# Altium schematic sheet SDCARD.SchDoc
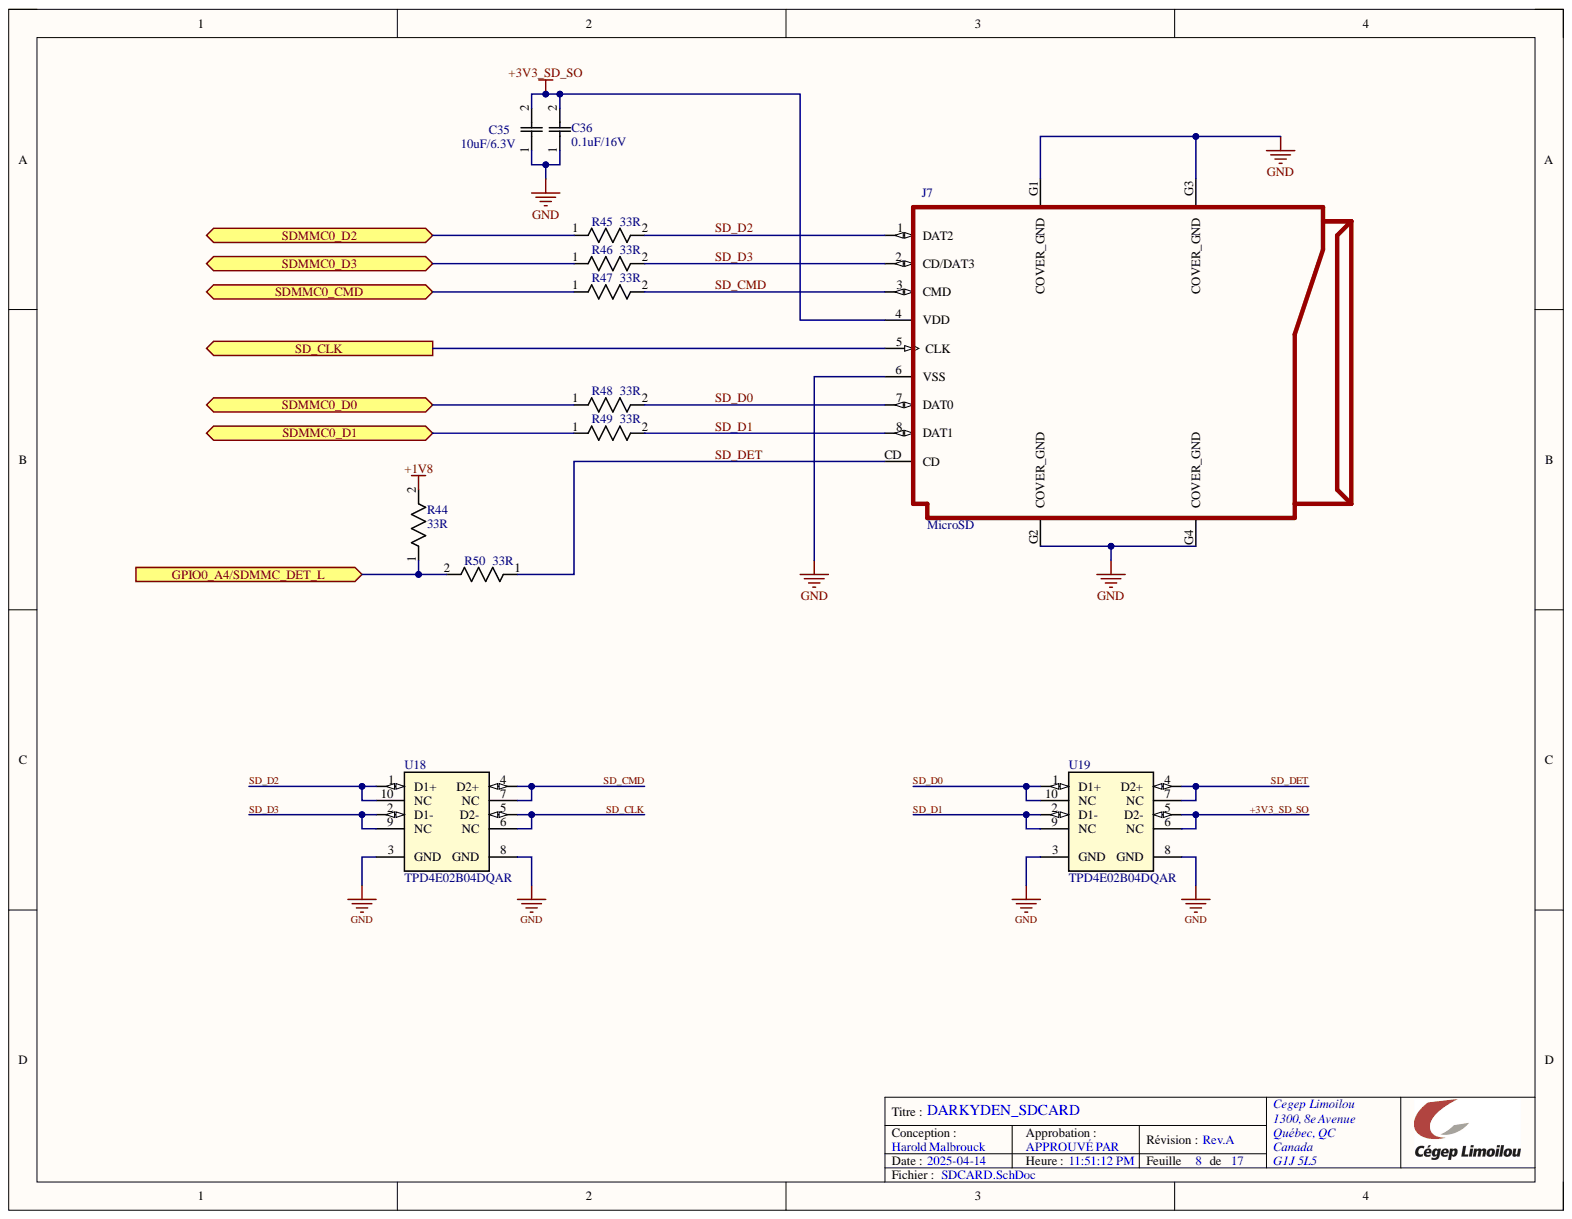
[diagram p0: SDCARD.SchDoc]

## Parts
C35  10uF/6.3V  [CAP_CC0805_YAG]
C36  0.1uF/16V  [CAP_CC0805_YAG]
J7  MicroSD  [CUI_MSD-4-A]
R44  33R  [RES_0816_SUS]
R45  33R  [RES_0816_SUS]
R46  33R  [RES_0816_SUS]
R47  33R  [RES_0816_SUS]
R48  33R  [RES_0816_SUS]
R49  33R  [RES_0816_SUS]
R50  33R  [RES_0816_SUS]
U18  TPD4E02B04DQAR  [DQA0010A]
U19  TPD4E02B04DQAR  [DQA0010A]

## Ports
GPIO0_A4/SDMMC_DET_L, SD_CLK, SDMMC0_CMD, SDMMC0_D0, SDMMC0_D1, SDMMC0_D2, SDMMC0_D3

## Nets
+1V8: R44.2
GND: C35.1 C36.1 J7.6(VSS) J7.G1(COVER_GND) J7.G2(COVER_GND) J7.G3(COVER_GND) J7.G4(COVER_GND) U18.3(GND) U18.8(GND) U19.3(GND) U19.8(GND)
+3V3_SD_SO: C35.2 C36.2 J7.4(VDD) U19.5(D2-) U19.6(NC)
GPIO0_A4/SDMMC_DET_L: R44.1 R50.2 ; port GPIO0_A4/SDMMC_DET_L
SD_CLK: J7.5(CLK) U18.5(D2-) U18.6(NC) ; port SD_CLK
SD_CMD: J7.3(CMD) R47.2 U18.4(D2+) U18.7(NC)
SD_D0: J7.7(DAT0) R48.2 U19.1(D1+) U19.10(NC)
SD_D1: J7.8(DAT1) R49.2 U19.2(D1-) U19.9(NC)
SD_D2: J7.1(DAT2) R45.2 U18.1(D1+) U18.10(NC)
SD_D3: J7.2(CD/DAT3) R46.2 U18.2(D1-) U18.9(NC)
SD_DET: J7.CD R50.1 U19.4(D2+) U19.7(NC)
SDMMC0_CMD: R47.1 ; port SDMMC0_CMD
SDMMC0_D0: R48.1 ; port SDMMC0_D0
SDMMC0_D1: R49.1 ; port SDMMC0_D1
SDMMC0_D2: R45.1 ; port SDMMC0_D2
SDMMC0_D3: R46.1 ; port SDMMC0_D3

## Library data of the parts
C35 C36 = Capacitor_0805: No Description Available; Mfr_Name: Yageo; Component Kind: Standard; Library Name: Capacitor_0805.SchLib
J7 = MSD-4-A: Micro SD Card Connector, 9 Positions, Connector and Ejector, Push In, Auto Eject Out, Surface Mount, 1.8 mm Height Above Board, Gold Flash; MF: Same Sky; Check_prices: https://www.snapeda.com/parts/MSD-4-A/Same+Sky/view-part/?ref=eda; Component Kind: Standard; Library Name: MSD-4-A.IntLib; MP: MSD-4-A; Package: None; SnapEDA_Link: https://www.snapeda.com/parts/MSD-4-A/Same+Sky/view-part/?ref=snap
R44-R50 = RG1608P-104-B-T5: No Description Available; Mfr_Name: Susumu; Component Kind: Standard; Library Name: RES_SMD_0603.SchLib
U18 U19 = TPD4E02B04DQAR: No Description Available; Manufacturer: Texas Instruments; CompType: IC; Component Kind: Standard; Library Name: TPD4E02B04DQAR.SchLib; Mfr_Name: Texas Instruments; MountType: SMT; PackageReference: DQA0010A; PartNumber: TPD4E02B04DQAR; RefDes: RefDes; RoHS: Y; TYPE: TYPE
